# Altium schematic sheet DUT-PowerSupplyMB-Rev2b.SchDoc(DUT-PowerSupplyMB-Rev2b) (continued)

## Library data of the parts
C28 C106 = Cap: CAP CER 0.1UF 250V X7R 1206; Manufacturer: KEMET; Manufacturer Part Number: C1206C104KARECAUTO; Tolerance: 10%; Case Code (Imperial): 1206; Case Code (Metric): 3216; Case/Package: 1206; Component Kind: Standard; Library Name: Miscellaneous Devices.IntLib; Max Operating Temperature: 125°C; Min Operating Temperature: -55°C; Mount: Surface Mount; Packaging: Cut Tape; Ratings: AEC-Q200; Signal Integrity: Cap; Simulation: CAP; Supplier: Digi-Key; Value: 0.1uF
  C28: Supplier Part Number: 399-17175-1-ND
  C106: Supplier Part Number: 399-17175-6-ND
C104 = Cap: CAP CER 330PF 500V COG 1206; Manufacturer: Yageo; Manufacturer Part Number: CC1206KRNPOBBN331; Tolerance: 10%; Capacitance: 330pF; Case Code (Imperial): 1206; Case Code (Metric): 3216; Case/Package: 1206; Component Kind: Standard; Height: 0.6mm; Length: 0.126inch; Library Name: Miscellaneous Devices.IntLib; Max Operating Temperature: 125°C; Min Operating Temperature: -55°C; Mount: Surface Mount; Package Quantity: 4000; Packaging: Tape and Reel; Resistor Type: Ceramic; RoHS Compliant: Yes; Series: CC; Signal Integrity: Cap; Simulation: CAP; Supplier: Digi-Key; Supplier Part Number: 311-4477-1-ND; Termination: SMD/SMT; Thickness: 0.028inch; Value: 330pF; Voltage Rating (DC): 500V; Weight: 0.000571oz; Width: 0.063inch
C105 C113 C115 C117 C119 C123 C125 C127 C129 C133 = Cap: CAP CER 10NF 100V X7R 0805; Manufacturer: Samsung; Manufacturer Part Number: CL21B103KCANNNC; Dielectric Material: Ceramic; Dissipation Factor: 2.5%; Tolerance: 10%; Capacitance: 10nF; Case Code (Imperial): 0805; Case Code (Metric): 2012; Case/Package: 0805; Component Kind: Standard; Construction: Flat; Contact Plating: Tin; Depth: 1.25mm; Height: 0.65mm; Length: 2mm; Library Name: Miscellaneous Devices.IntLib; Material: Ceramic; Max Operating Temperature: 125°C; Min Operating Temperature: -55°C; Mount: Surface Mount; Number of Pins: 2; Package Quantity: 1; Packaging: Tape and Reel; Radiation Hardening: No; REACH SVHC: No SVHC; RoHS Compliant: Yes; Series: CL; Signal Integrity: Cap; Simulation: CAP; Supplier: Digi-Key; Supplier Part Number: 1276-1249-6-ND; Termination: SMD/SMT; Thickness: 0.03inch; Value: 10nF; Voltage Rating: 100V; Voltage Rating (DC): 100V; Width: 0.049inch
  C105 C115 C125 C129: Voltage: 100V
C107 = Cap: CAP CER 100PF 50V COG 0805; Manufacturer: KEMET; Manufacturer Part Number: C0805C101J5GACTU; Dielectric Material: Ceramic; Dissipation Factor: 0.1%; Temperature Coefficient: 30ppm/°C; Tolerance: 5%; Capacitance: 100pF; Case Code (Imperial): 0805; Case Code (Metric): 2012; Case/Package: 0805; Component Kind: Standard; Construction: Flat; Contact Material: Tin; Contact Plating: Tin,Matte; Depth: 1.25mm; Height: 0.78mm; Lead Free: Lead Free; Lead Pitch: 0.75mm; Length: 2mm; Library Name: Miscellaneous Devices.IntLib; Max Operating Temperature: 125°C; Min Operating Temperature: -55°C; Mount: Surface Mount,PCB; Number of Pins: 2; Package Quantity: 4000; Packaging: Tape and Reel; Radiation Hardening: No; REACH SVHC: No SVHC; RoHS Compliant: Yes; Series: C; Signal Integrity: Cap; Simulation: CAP; Supplier: Digi-Key; Supplier Part Number: 399-1122-6-ND; Termination: SMD/SMT; Thickness: 0.035inch; Value: 100pF; Voltage: 50V; Voltage Rating: 50V; Voltage Rating (DC): 50V; Width: 1.2mm
C108 C112 C114 C116 C118 C120 C124 C126 C128 C130 C132 C134 = Cap: CAP CER 1UF 50V X7R 0805; Manufacturer: Samsung; Manufacturer Part Number: CL21B105KBFNNNE; Dielectric Material: Ceramic; Tolerance: 10%; Capacitance: 1uF; Case Code (Imperial): 0805; Case Code (Metric): 2012; Case/Package: 0805; Component Kind: Standard; Construction: Flat; Depth: 1.25mm; Height: 1.25mm; Length: 2mm; Library Name: Miscellaneous Devices.IntLib; Max Operating Temperature: 125°C; Min Operating Temperature: -55°C; Mount: Surface Mount; Number of Pins: 2; Package Quantity: 1; Packaging: Tape and Reel; Radiation Hardening: No; REACH SVHC: No SVHC; RoHS Compliant: Yes; Series: CL; Signal Integrity: Cap; Simulation: CAP; Supplier: Digi-Key; Supplier Part Number: 1276-1029-6-ND; Termination: SMD/SMT; Thickness: 0.053inch; Value: 1uF; Voltage Rating: 50V; Voltage Rating (DC): 50V; Width: 0.049inch
C109 = Cap: CAP CER 47PF 250V C0G/NP0 0805; Manufacturer: KEMET; Manufacturer Part Number: C0805C470JAGAC7800; Tolerance: 5%; Capacitance: 47pF; Case Code (Imperial): 0805; Case Code (Metric): 2012; Case/Package: 0805; Component Kind: Standard; Library Name: Miscellaneous Devices.IntLib; Max Operating Temperature: 125°C; Min Operating Temperature: -55°C; Mount: Surface Mount; Packaging: Tape and Reel; Signal Integrity: Cap; Simulation: CAP; Supplier: Digi-Key; Supplier Part Number: 399-15051-6-ND; Value: 47pF
C111 C121 C131 C135 = Cap Pol1: Aluminum Electrolytic Capacitors - Leaded 100uF ±20% 25V Radial, 5x11mm RoHS; Manufacturer: Rubycon; Manufacturer Part Number: 25YXJ100M5X11; Impedance: 400mR; Lead Diameter: 0.5mm; Leakage Current: 3uA; Life (Hours): 5000Hour; Polarity: Polar; Ripple Current: 250mA; Capacitance: 100uF; Case/Package: Radial; Category: Aluminum Electrolytic Capacitors; Component Kind: Standard; ComponentLink1Description: https://datasheet.ciiva.com/11777/0900766b810307a1-11777001.pdf?src-supplier=RSComponents; ComponentLink1URL: https://datasheet.ciiva.com/11777/0900766b810307a1-11777001.pdf?src-supplier=RSComponents; ComponentLink2Description: https://datasheet.ciiva.com/41806/e-yxj-41806041.pdf?src-supplier=Digi-Key; ComponentLink2URL: https://datasheet.ciiva.com/41806/e-yxj-41806041.pdf?src-supplier=Digi-Key; ComponentLink3Description: https://datasheet.ciiva.com/11690/e-yxj-11690904.pdf?src-supplier=Avnet; ComponentLink3URL: https://datasheet.ciiva.com/11690/e-yxj-11690904.pdf?src-supplier=Avnet; Construction: RADIAL; Diameter: 5mm; Height: 11mm; Height - Seated (Max): 0.492inch; Lead Pitch: 2mm; Library Name: Miscellaneous Devices.IntLib; Max Operating Temperature: 105°C; Min Operating Temperature: -40°C; Mount: Through Hole; Package Quantity: 3000; Packaging: Bulk; Pricing 1: 1=0.25000, 10=0.17200, 50=0.10360, 100=0.08740, 500=0.05980, 1000=0.05290, 2500=0.05060, 5000=0.04600 (USD); Radiation Hardening: No; RoHS: Yes; RoHS Compliant: Yes; Series: YXJ; Signal Integrity: Cap Pol; Simulation: CAP; Stock 1: 7789; Supplier: Digi-Key; Supplier Part Number: 1189-2237-ND; Termination: Radial; Tolerance: 20%; Value: 100uF; Voltage Rating: 25V
D102 D103 D105 = Diode 1N4148: DIODE GP 75V 150MA SOD123FL; Manufacturer: Vishay Semiconductors; Manufacturer Part Number: 1N4148WFL-G3-08; Element Configuration: Single; Forward Voltage: 1.2V; Max Power Dissipation: 350mW; Max Repetitive Reverse Voltage (Vrrm): 100V; Max Reverse Current: 100uA; Max Surge Current: 500mA; Recovery Time: 4ns; Average Rectified Current: 150mA; Component Kind: Standard; ComponentLink1Description: Manufacturer Link; ComponentLink1URL: http://www.fairchildsemi.com/; ComponentLink2Description: Datasheet; ComponentLink2URL: http://www.fairchildsemi.com/ds/1N%2F1N4148.pdf; Contact Plating: Tin; Forward Current: 150mA; Height: 1mm; Length: 2.7mm; Library Name: Miscellaneous Devices.IntLib; Max Operating Temperature: 125°C; Max Reverse Voltage (DC): 75V; Min Operating Temperature: -65°C; Mount: Surface Mount; Number of Pins: 2; Package Quantity: 3000; Packaging: Tape and Reel; Peak Non-Repetitive Surge Current: 500mA; Reverse Recovery Time: 4ns; RoHS Compliant: Yes; Signal Integrity: 1N4148; Simulation: 1N4148; Supplier: Digi-Key; Supplier Part Number: 1N4148WFL-G3-08GIDKR-ND; Termination: SMD/SMT; Value: 1N4148; Width: 1.7mm
D104 D106 = Dual-Sch-Series-Separate: DIODE ARRAY SCHOTTKY 30V SOT23-3; Manufacturer: ON Semiconductor / Fairchild; Average Rectified Current: 200mA; Capacitance: 10pF; Current Rating: 200A; Forward Voltage: 800mV; Max Forward Surge Current (Ifsm): 600mA; Max Reverse Current: 2uA; Power Dissipation: 290mW; Recovery Time: 5ns; Case/Package: SOT-23; Component Kind: Standard; Contact Plating: Tin,Matte; Forward Current: 200mA; Height: 0.93mm; Lead Free: Lead Free; Length: 2.92mm; Library Name: MY_Diodes.SchLib; Manufacturer Part Number: BAT54S; Max Operating Temperature: 150°C; Max Repetitive Reverse Voltage (Vrrm): 30V; Max Reverse Leakage Current: 2uA; Max Reverse Voltage (DC): 30V; Max Surge Current: 600mA; Min Operating Temperature: -55°C; Mount: Surface Mount; Number of Pins: 3; Package Quantity: 1; Packaging: Tape and Reel; Peak Non-Repetitive Surge Current: 600mA; Radiation Hardening: No; REACH SVHC: No SVHC; Reverse Recovery Time: 5ns; RoHS Compliant: Yes; Supplier: Digi-Key; Supplier Part Number: BAT54SFSCT-ND; Value: BAT54S; Voltage Rating (DC): 30V; Weight: 0.025g; Width: 1.3mm
HS100 HS101 = HeatSink: Heat Sinks HTSNK TO-220 218 247 BLK ANODIZED; Manufacturer: Ohmite; Natural Thermal Resistance: 3°C/W; Shape: Fins,Rectangular; Thermal Resistance @ Forced Air Flow (100 LFM): 2°C/W; Case/Package: TO-220; Color: Black; Component Kind: Standard; Finish: Anodized; Height: 63.5mm; Height Off Base: 0.984inch; Length: 41.6mm; Library Name: MY_HeatSink.SchLib; Material: Aluminium; Mount: Bolt,PC Pin,Screw,Vertical; Package Cooled: TO-220; Package Quantity: 10; Packaging: Bulk; REACH SVHC: Unknown; RoHS Compliant: Yes; Series: F; Supplier: Digi-Key; Supplier Part Number: FA-T220-64E-ND; Type: Passive; Weight: 46g; Width: 25mm
J102 J105 = 2-Pin: 2.54mm 2pin; Manufacturer: TE Connectivity; Manufacturer Part Number: 640456-2; Approvals: CSA; Backwall/Post Interruptions: No (Without); Circuit Application: Signal; Connector System: Wire-to-Board; Connector Type: Connector,Pin,Plug,Header; Contact Current Rating: 5A; Contact Post Length: 3.56mm; Contact Resistance: 6mR; Contact Style: Straight; Gender: Male; Mating Connector Lock: Yes (With); Max Voltage Rating (AC): 250V; Number of Contacts: 2; PCB Mount Retention: Without; PCB Mounting Orientation: Vertical; PCB Thickness: 0.063inch; Plating Thickness: 3.81um; Tail Length: 0.14inch; Value: 640456-2; Category: Headers and Wire Housings; Color: Natural,White,Beige; Component Kind: Standard; ComponentLink1Description: https://datasheet.ciiva.com/709/eng-cd-640456-w1-709680.pdf?src-supplier=Digi-Key; ComponentLink1URL: https://datasheet.ciiva.com/709/eng-cd-640456-w1-709680.pdf?src-supplier=Digi-Key; ComponentLink2Description: https://datasheet.ciiva.com/3118/eng-ds-82056-mta-0608-3118497.pdf?src-supplier=Avnet; ComponentLink2URL: https://datasheet.ciiva.com/3118/eng-ds-82056-mta-0608-3118497.pdf?src-supplier=Avnet; ComponentLink3Description: https://datasheet.ciiva.com/16401/eng-ds-82056-mta-0608-16401289.pdf?src-supplier=Future+Electronics; ComponentLink3URL: https://datasheet.ciiva.com/16401/eng-ds-82056-mta-0608-16401289.pdf?src-supplier=Future+Electronics; ComponentLink4Description: https://datasheet.ciiva.com/25617/0900766b80027008-25617244.pdf?src-supplier=RSComponents; ComponentLink4URL: https://datasheet.ciiva.com/25617/0900766b80027008-25617244.pdf?src-supplier=RSComponents; ComponentLink5Description: https://datasheet.ciiva.com/14156/04384301-14156820.pdf?src-supplier=Distrelec; ComponentLink5URL: https://datasheet.ciiva.com/14156/04384301-14156820.pdf?src-supplier=Distrelec; ComponentLink6Description: https://datasheet.ciiva.com/11746/0900766b80eb7fdd-11746365.pdf?src-supplier=RSComponents; ComponentLink6URL: https://datasheet.ciiva.com/11746/0900766b80eb7fdd-11746365.pdf?src-supplier=RSComponents; ComponentLink7Description: https://datasheet.ciiva.com/7128/1866390-7128742.pdf?src-supplier=Newark; ComponentLink7URL: https://datasheet.ciiva.com/7128/1866390-7128742.pdf?src-supplier=Newark; ComponentLink8Description: https://datasheet.ciiva.com/16505/eng-ds-82056-mta-0608-16505419.pdf?src-supplier=Avnet+Asia; ComponentLink8URL: https://datasheet.ciiva.com/16505/eng-ds-82056-mta-0608-16505419.pdf?src-supplier=Avnet+Asia; ComponentLink9Description: https://datasheet.ciiva.com/18620/1713017-18620870.pdf?src-supplier=Digi-Key; ComponentLink9URL: https://datasheet.ciiva.com/18620/1713017-18620870.pdf?src-supplier=Digi-Key; ComponentLink10Description: https://datasheet.ciiva.com/16047/1989748-16047438.pdf?src-supplier=Onlinecomponents.com; ComponentLink10URL: https://datasheet.ciiva.com/16047/1989748-16047438.pdf?src-supplier=Onlinecomponents.com; ComponentLink11Description: https://datasheet.ciiva.com/11758/0900766b811d404e-11758587.pdf?src-supplier=RSComponents; ComponentLink11URL: https://datasheet.ciiva.com/11758/0900766b811d404e-11758587.pdf?src-supplier=RSComponents; ComponentLink12Description: https://datasheet.ciiva.com/6746/combiconspring-cagepcbterm-blocks-6746175.pdf?src-supplier=Digi-Key; ComponentLink12URL: https://datasheet.ciiva.com/6746/combiconspring-cagepcbterm-blocks-6746175.pdf?src-supplier=Digi-Key; ComponentLink13Description: https://datasheet.ciiva.com/14607/70055059-14607702.pdf?src-supplier=Allied+Electronics; ComponentLink13URL: https://datasheet.ciiva.com/14607/70055059-14607702.pdf?src-supplier=Allied+Electronics; ComponentLink14Description: https://datasheet.ciiva.com/16051/1988956-16051359.pdf?src-supplier=Onlinecomponents.com; ComponentLink14URL: https://datasheet.ciiva.com/16051/1988956-16051359.pdf?src-supplier=Onlinecomponents.com; ComponentLink15Description: https://datasheet.ciiva.com/26340/567541-da-01-de-printklemme-1988956-250-st-26340451.pdf?src-supplier=Conrad; ComponentLink15URL: https://datasheet.ciiva.com/26340/567541-da-01-de-printklemme-1988956-250-st-26340451.pdf?src-supplier=Conrad; ComponentLink16Description: https://datasheet.ciiva.com/824/combiconcompactseries-824224.pdf?src-supplier=Digi-Key; ComponentLink16URL: https://datasheet.ciiva.com/824/combiconcompactseries-824224.pdf?src-supplier=Digi-Key; ComponentLink17Description: https://datasheet.ciiva.com/14609/70055171-14609100.pdf?src-supplier=Allied+Electronics; ComponentLink17URL: https://datasheet.ciiva.com/14609/70055171-14609100.pdf?src-supplier=Allied+Electronics; Contact Gender: Male; Contact Material: Copper Alloy; Contact Mating Length: 7.49mm; Contact Plating: Tin; Contact Retention: No (Without); Contact Shape: Square; Contact Type: Male Pin; Current Rating: 5A; Depth: 5.72mm; ELV: Compliant; Fastening Type: Friction; Flammability Rating: UL94 V-0; Height: 7.87mm; Housing Color: Natural; Housing Material: Polyester; Lead Free: Lead Free; Lead Pitch: 2.54mm; Length: 7.62mm; Library Name: MY_CONNECTORS.SchLib; Mating Alignment: No (Without); Mating Retention: No (Without); Max Operating Temperature: 221°C; Min Operating Temperature: -67°C; Mount: Through Hole; Mounting Ears: No (Without); Number of Poles: 2; Number of Positions: 2; Number of Rows: 1; Orientation: Straight; Package Quantity: 5000; Packaging: Bulk; Panel Mount Retention: No (Without); PCB Mount Alignment: No (Without); Pitch: 2.54mm; Pricing 1: 1=0.13000, 10=0.10400, 100=0.07700, 500=0.06374, 1000=0.05578, 5000=0.04781, 10000=0.04648 (USD); Radiation Hardening: No; REACH SVHC: No SVHC; RoHS: Yes; RoHS Compliant: Yes; Sealable: No (Without); Series: MTA-100; Shrouded: No (Without); Stock 1: 18986; Strain Relief: No (Without); Supplier: Digi-Key; Supplier Part Number: A1921-ND; Termination: Solder; Voltage Rating: 250V; Voltage Rating (AC): 250V; Working Voltage: 250V
J103 J106 = 3-Pin: 2.54mm 3 pin; Manufacturer: TE Connectivity; Manufacturer Part Number: 640456-3; Approvals: CSA; Backwall/Post Interruptions: No (Without); Circuit Application: Signal; Connector System: Wire-to-Board; Connector Type: Connector,Pin,Plug,Header; Contact Current Rating: 5A; Contact Post Length: 3.56mm; Contact Resistance: 6mR; Contact Style: Straight; Gender: Male; Mating Connector Lock: Yes (With); Max Voltage Rating (AC): 250V; Number of Contacts: 3; PCB Mount Retention: Without; PCB Mounting Orientation: Vertical; PCB Thickness: 0.063inch; Plating Thickness: 3.81um; Tail Length: 0.14inch; Value: 640456-3; Category: Connectors; Color: Beige,White; Component Kind: Standard; ComponentLink1Description: http://www.farnell.com/datasheets/2043229.pdf?src-supplier=Farnell; ComponentLink1URL: http://www.farnell.com/datasheets/2043229.pdf?src-supplier=Farnell; ComponentLink2Description: http://www.farnell.com/datasheets/2043229.pdf?src-supplier=Element14; ComponentLink2URL: http://www.farnell.com/datasheets/2043229.pdf?src-supplier=Element14; ComponentLink3Description: http://www.farnell.com/datasheets/2043229.pdf?src-supplier=Newark; ComponentLink3URL: http://www.farnell.com/datasheets/2043229.pdf?src-supplier=Newark; ComponentLink4Description: https://www.te.com/commerce/DocumentDelivery/DDEController?Action=srchrtrv&DocNm=640456&DocType=Customer+Drawing&DocLang=English&src-supplier=Digi-Key; ComponentLink4URL: https://www.te.com/commerce/DocumentDelivery/DDEController?Action=srchrtrv&DocNm=640456&DocType=Customer+Drawing&DocLang=English&src-supplier=Digi-Key; ComponentLink5Description: https://datasheet.ciiva.com/11746/0900766b80027008-11746172.pdf?src-supplier=RSComponents; ComponentLink5URL: https://datasheet.ciiva.com/11746/0900766b80027008-11746172.pdf?src-supplier=RSComponents; ComponentLink6Description: https://datasheet.ciiva.com/6005/1712135-6005440.pdf?src-supplier=Farnell; ComponentLink6URL: https://datasheet.ciiva.com/6005/1712135-6005440.pdf?src-supplier=Farnell; ComponentLink7Description: https://datasheet.ciiva.com/19474/613940-19474164.pdf?src-supplier=Jameco; ComponentLink7URL: https://datasheet.ciiva.com/19474/613940-19474164.pdf?src-supplier=Jameco; ComponentLink8Description: https://datasheet.ciiva.com/16456/eng-ds-82056-mta-0608-16456886.pdf?src-supplier=Future+Electronics; ComponentLink8URL: https://datasheet.ciiva.com/16456/eng-ds-82056-mta-0608-16456886.pdf?src-supplier=Future+Electronics; ComponentLink9Description: https://datasheet.ciiva.com/14156/04384301-14156821.pdf?src-supplier=Distrelec; ComponentLink9URL: https://datasheet.ciiva.com/14156/04384301-14156821.pdf?src-supplier=Distrelec; ComponentLink10Description: https://datasheet.ciiva.com/16656/eng-ds-82056-mta-0608-16656523.pdf?src-supplier=Avnet+Europe; ComponentLink10URL: https://datasheet.ciiva.com/16656/eng-ds-82056-mta-0608-16656523.pdf?src-supplier=Avnet+Europe; ComponentLink11Description: https://datasheet.ciiva.com/30127/8852eng-ds-82056-mta-0608-30127480.pdf?src-supplier=Onlinecomponents.com; ComponentLink11URL: https://datasheet.ciiva.com/30127/8852eng-ds-82056-mta-0608-30127480.pdf?src-supplier=Onlinecomponents.com; ComponentLink12Description: https://datasheet.ciiva.com/14637/70083643-14637750.pdf?src-supplier=Allied+Electronics; ComponentLink12URL: https://datasheet.ciiva.com/14637/70083643-14637750.pdf?src-supplier=Allied+Electronics; ComponentLink13Description: https://datasheet.ciiva.com/7128/eng-ds-82056-mta-0608-7128744.pdf?src-supplier=Newark; ComponentLink13URL: https://datasheet.ciiva.com/7128/eng-ds-82056-mta-0608-7128744.pdf?src-supplier=Newark; ComponentLink14Description: https://datasheet.ciiva.com/709/eng-cd-640456-w2-709688.pdf?src-supplier=Digi-Key; ComponentLink14URL: https://datasheet.ciiva.com/709/eng-cd-640456-w2-709688.pdf?src-supplier=Digi-Key; ComponentLink15Description: https://datasheet.ciiva.com/16047/1984976-16047357.pdf?src-supplier=Onlinecomponents.com; ComponentLink15URL: https://datasheet.ciiva.com/16047/1984976-16047357.pdf?src-supplier=Onlinecomponents.com; ComponentLink16Description: https://datasheet.ciiva.com/11758/0900766b811d406d-11758596.pdf?src-supplier=RSComponents; ComponentLink16URL: https://datasheet.ciiva.com/11758/0900766b811d406d-11758596.pdf?src-supplier=RSComponents; ComponentLink17Description: https://datasheet.ciiva.com/26840/getdatasheetpartid-743835-26840596.pdf?src-supplier=Verical; ComponentLink17URL: https://datasheet.ciiva.com/26840/getdatasheetpartid-743835-26840596.pdf?src-supplier=Verical; ComponentLink18Description: https://datasheet.ciiva.com/6752/combiconspring-cagepcbterm-blocks-6752927.pdf?src-supplier=Digi-Key; ComponentLink18URL: https://datasheet.ciiva.com/6752/combiconspring-cagepcbterm-blocks-6752927.pdf?src-supplier=Digi-Key; ComponentLink19Description: https://datasheet.ciiva.com/16051/1988969-16051361.pdf?src-supplier=Onlinecomponents.com; ComponentLink19URL: https://datasheet.ciiva.com/16051/1988969-16051361.pdf?src-supplier=Onlinecomponents.com; ComponentLink20Description: https://datasheet.ciiva.com/823/combiconcompactseries-823768.pdf?src-supplier=Digi-Key; ComponentLink20URL: https://datasheet.ciiva.com/823/combiconcompactseries-823768.pdf?src-supplier=Digi-Key; ComponentLink21Description: https://datasheet.ciiva.com/14609/70055172-14609515.pdf?src-supplier=Allied+Electronics; ComponentLink21URL: https://datasheet.ciiva.com/14609/70055172-14609515.pdf?src-supplier=Allied+Electronics; Contact Finish - Mating: TIN; Contact Gender: Male; Contact Material: Copper Alloy; Contact Mating Length: 7.49mm; Contact Plating: Tin; Contact Retention: No (Without); Contact Shape: Square; Contact Type: Male Pin; Current Rating: 5A; Depth: 5.72mm; ELV: Compliant; Fastening Type: Friction; Flammability Rating: UL94 V-0; Height: 7.87mm; Housing Color: Natural; Housing Material: Polyester; Insulation Color: Natural; Insulation Material: Thermoplastic; Lead Free: Lead Free; Length: 0.4inch; Library Name: MY_CONNECTORS.SchLib; Mating Alignment: No (Without); Mating Retention: No (Without); Max Operating Temperature: 221°C; Min Operating Temperature: -67°C; Mount: Through Hole; Mounting Ears: No (Without); Number of Positions: 3; Number of Rows: 1; Orientation: Straight; Package Quantity: 5000; Packaging: Bulk; Panel Mount Retention: No (Without); PCB Mount Alignment: No (Without); Pitch: 2.54mm; Pricing 1: 1=0.16000, 10=0.13500, 100=0.10360, 500=0.08766, 1000=0.07173, 5000=0.06376, 10000=0.05977 (USD); Radiation Hardening: No; REACH SVHC: Unknown; RoHS: Yes; RoHS Compliant: Yes; Sealable: No (Without); Series: MTA-100; Shrouded: No (Without); Stock 1: 96416; Strain Relief: No (Without); Supplier: Digi-Key; Supplier Part Number: A19470-ND; Termination: Solder; Voltage Rating: 250V; Voltage Rating (AC): 250V
Pot100 Pot102 = POT-Single: TRIMMER 50 OHM 0.5W TH; Manufacturer: Nidec Copal; Manufacturer Part Number: CT-6EP500; Max Power Dissipation: 500mW; Number of Turns: 1; Temperature Coefficient: 100ppm/°C; Tolerance: 10%; Component Kind: Standard; Contact Plating: Tin; Length: 0.228inch; Max Operating Temperature: 120°C; Min Operating Temperature: -55°C; Mount: Through Hole; Package Quantity: 50; Packaging: Bulk; Power Rating: 500mW; Resistor Type: Cermet; Series: CT6; Supplier: Digi-Key; Supplier Part Number: CT6EP500-ND; Termination: PC Pin; Library Name: MY_POTS.SchLib
Pot101 = POT-Single: TRIMMER 500 OHM 0.5W TH; Manufacturer: Nidec Copal; Manufacturer Part Number: CT-6EP501; Max Power Dissipation: 500mW; Number of Turns: 1; Temperature Coefficient: 100ppm/°C; Tolerance: 10%; Color: Blue; Component Kind: Standard; Contact Plating: Tin; Lead Free: Lead Free; Length: 0.228inch; Max Operating Temperature: 120°C; Min Operating Temperature: -55°C; Mount: Through Hole; Package Quantity: 50; Packaging: Bulk; Resistor Type: Cermet; Series: CT6; Supplier: Digi-Key; Supplier Part Number: CT6EP501-ND; Termination: PC Pin; Weight: 510mg; Library Name: MY_POTS.SchLib
Pot103 = POT-Single: TRIMMER 2K OHM 0.2W TH; Manufacturer: Bourns; Manufacturer Part Number: 3306P-1-202; Max Power Dissipation: 200mW; Number of Turns: 1; Pitch: 2.5mm; Resistance: 2kR; Resistance Tolerance: 25%; Temperature Coefficient: 250ppm/°C; Voltage Rating: 100V; Color: Blue; Component Kind: Standard; Depth: 8.1mm; Diameter: 6.81mm; Height: 7.62mm; Lead Free: Lead Free; Length: 6.81mm; Max Operating Temperature: 100°C; Military Standard: Not; Min Operating Temperature: -25°C; Mount: Through Hole,PCB; Package Quantity: 200; Packaging: Bulk; Power Rating: 200mW; Radiation Hardening: No; REACH SVHC: No SVHC; Resistor Type: Cermet; Series: Trimpot® 3306 - Open Frame; Supplier: Digi-Key; Supplier Part Number: 3306P-202-ND; Taper: Linear; Technology: CERMET; Termination: Pin; Tolerance: 25%; Weight: 360mg; Width: 6.81mm; Library Name: MY_POTS.SchLib
Q103 Q113 = Dual-PNP: Dual Matched Pair PNP SOT363-6M; Manufacturer: NXP Semiconductors; Manufacturer Part Number: BCM857DS,135; Collector Base Voltage (VCBO): 50V; Collector Emitter Breakdown Voltage: 45V; Collector-emitter Voltage-Max: 400mV; Emitter Base Voltage (VEBO): 5V; Frequency: 175MHz; Max Collector Current: 100mA; Max Power Dissipation: 380mW; Number of Elements: 2; Case/Package: TSOP; Collector Emitter Voltage (VCEO): 45V; Component Kind: Standard; Contact Plating: Tin,Matte; Element Configuration: Dual; Gain Bandwidth Product: 175MHz; Library Name: MY_Transistor.SchLib; Max Breakdown Voltage: 45V; Max Operating Temperature: 150°C; Min Operating Temperature: -65°C; Mount: Surface Mount; Number of Pins: 6; Package Quantity: 1; Packaging: Tape and Reel; Polarity: PNP; Power Dissipation: 380mW; RoHS Compliant: Yes; Series: -; Supplier: Digi-Key; Supplier Part Number: 1727-5460-1-ND; Transition Frequency: 175MHz; Value: BCM857DS
Q104 Q105 = NMOS-2: N-Channel Power MOSFET; Manufacturer: STMicroelectronics; Manufacturer Part Number: STW28N60M2; Continuous Drain Current (ID): 24A; Drain to Source Breakdown Voltage: 600V; Drain to Source Resistance: 120mR; Element Configuration: Single; Fall Time: 8ns; Gate to Source Voltage (Vgs): 25V; Input Capacitance: 1.37nF; Max Power Dissipation: 190W; Number of Channels: 1; Power Dissipation: 170W; Rds On Max: 150mR; Rise Time: 7.2ns; Turn-Off Delay Time: 100ns; Turn-On Delay Time: 14.5ns; Case/Package: TO-247; Component Kind: Standard; ComponentLink1Description: Manufacturer Link; ComponentLink1URL: http://www.irf.com/; Contact Plating: Tin,Matte; Drain to Source Voltage (Vdss): 600V; Height: 20.15mm; Lead Free: Lead Free; Length: 15.75mm; Library Name: Miscellaneous Devices.IntLib; Max Operating Temperature: 150°C; Min Operating Temperature: -55°C; Mount: Through Hole; Number of Elements: 1; Number of Pins: 3; Package Quantity: 30; Packaging: Rail/Tube; Simulation: IRF1010; Supplier: Digi-Key; Supplier Part Number: 497-14292-5-ND; Value: STW28N60; Weight: 1.340411oz; Width: 5.15mm
Q106 Q108 Q109 Q111 Q112 = 2N7000: N-CH 25V 1.25 W Power Mosfet SOT-23; Manufacturer: International Rectifier; Manufacturer Part Number: IRFML8244TRPBF; Continuous Drain Current (ID): 5.8A; Drain to Source Resistance: 41mR; Element Configuration: Single; Fall Time: 2.9ns; Gate to Source Voltage (Vgs): 20V; Input Capacitance: 430pF; Max Power Dissipation: 1.25W; Nominal Vgs: 1.7V; Number of Elements: 1; Rds On Max: 24mR; Recovery Time: 17ns; Rise Time: 2.1ns; Turn-Off Delay Time: 9ns; Turn-On Delay Time: 2.7ns; Case/Package: SOT-23; Component Kind: Standard; Drain to Source Breakdown Voltage: 25V; Drain to Source Voltage (Vdss): 25V; Drain-source On Resistance-Max: 41MR; Height: 1.02mm; Lead Free: Lead Free; Length: 3.04mm; Library Name: Philips Discrete MOSFET - Low Power.IntLib; Max Operating Temperature: 150°C; Min Operating Temperature: -55°C; Mount: Surface Mount; Number of Pins: 3; On-State Resistance: 41MR; Package Quantity: 1; Packaging: Tape and Reel; Power Dissipation: 1.25W; Radiation Hardening: No; REACH SVHC: No SVHC; Series: HEXFET®; Simulation: 2N7000; Supplier: Digi-Key; Supplier Part Number: IRFML8244TRPBFDKR-ND; Threshold Voltage: 1.7V; Value: IRFML8244; Width: 1.4mm
Q107 Q115 = Dual-NPN: Dual Matched Pair NPN SOT363-6M; Manufacturer: NXP Semiconductors; Manufacturer Part Number: BCM847DS,135; Collector Base Voltage (VCBO): 50V; Collector Emitter Breakdown Voltage: 45V; Collector-emitter Voltage-Max: 400mV; Emitter Base Voltage (VEBO): 6V; Frequency: 250MHz; Max Collector Current: 100mA; Max Power Dissipation: 380mW; Number of Elements: 2; Case/Package: TSOP; Collector Emitter Voltage (VCEO): 45V; Component Kind: Standard; Contact Plating: Tin; Element Configuration: Dual; Gain Bandwidth Product: 250MHz; Library Name: MY_Transistor.SchLib; Max Breakdown Voltage: 45V; Max Operating Temperature: 150°C; Min Operating Temperature: -65°C; Mount: Surface Mount; Number of Pins: 6; Package Quantity: 1; Packaging: Tape and Reel; Polarity: NPN; Power Dissipation: 380mW; Radiation Hardening: No; RoHS Compliant: Yes; Series: -; Supplier: Digi-Key; Supplier Part Number: 1727-5458-1-ND; Transition Frequency: 250MHz; Value: BCM847DS
Q110 = 2N3906: TRANS PNP 40V 0.2A SOT23; Manufacturer: ON Semiconductor; Manufacturer Part Number: MMBT3906LT1G; Current - Collector (Ic) (Max): 200mA; DC Current Gain (hFE) (Min) @ Ic, Vce: 100 @ 10mA, 1V; Frequency - Transition: 250MHz; Power - Max: 300mW; Vce Saturation (Max) @ Ib, Ic: 400mV @ 5mA, 50mA; Category: Discrete Semiconductor Products; Component Kind: Standard; Library Name: Miscellaneous Devices.IntLib; Mounting Type: Surface Mount; Package / Case: TO-236-3, SC-59, SOT-23-3; Packaging: Cut Tape (CT); RoHS: RoHS Compliant; Signal Integrity: 2N3906; Simulation: 2N3906; Supplier: Digi-Key; Supplier Device Package: SOT-23-3 (TO-236); Supplier Part Number: MMBT3906LT1GOSCT-ND; Transistor Type: PNP; Value: 2N3906; Voltage - Collector Emitter Breakdown (Max): 40V
Q114 = 2N3904: TRANS NPN 40V 0.2A SOT23; Manufacturer: ON Semiconductor; Manufacturer Part Number: MMBT3904LT1G; Current - Collector (Ic) (Max): 200mA; DC Current Gain (hFE) (Min) @ Ic, Vce: 100 @ 10mA, 1V; Frequency - Transition: 300MHz; Power - Max: 300mW; Vce Saturation (Max) @ Ib, Ic: 300mV @ 5mA, 50mA; Category: Discrete Semiconductor Products; Code_JEDEC: TO-92A; Component Kind: Standard; ComponentLink1Description: Manufacturer Link; ComponentLink1URL: http://www.fairchildsemi.com/; ComponentLink2Description: Datasheet; ComponentLink2URL: http://www.fairchildsemi.com/ds/2N%2F2N3904.pdf; LatestRevisionDate: 15-Jan-2003; LatestRevisionNote: PCB Footprint 'BCY-W3/D4.7' replaced; Library Name: My_Miscellaneous Devices.SchLib; Mounting Type: Surface Mount; Operating Temperature: -55°C ~ 150°C (TJ); Package / Case: TO-236-3, SC-59, SOT-23-3; PackageVersion: Sep-1998; Packaging: Cut Tape (CT); Part Status: Active; RoHS: RoHS Compliant; Signal Integrity: 2N3904; Simulation: 2N3904; Supplier: Digi-Key; Supplier Device Package: SOT-23-3 (TO-236); Supplier Part Number: MMBT3904LT1GOSCT-ND; Transistor Type: NPN; Value: 2N3904; Voltage - Collector Emitter Breakdown (Max): 40V
R2 = Res3: Res Thick Film 1206 301K Ohm 1% 0.25W ±100ppm/°C Molded SMD Paper T/R; Manufacturer Part Number: RMCF1206FT301K; Max Power Dissipation: 250mW; Resistance: 301kR; Case Code (Imperial): 1206; Case Code (Metric): 3216; Case/Package: 1206; Category: Chip SMD Resistors; Component Kind: Standard; ComponentLink1Description: http://components.arrow.com/part/dataview/40949670S6804816N1174?src-supplier=Arrow+Electronics; ComponentLink1URL: http://components.arrow.com/part/dataview/40949670S6804816N1174?src-supplier=Arrow+Electronics; ComponentLink2Description: https://www.seielect.com/catalog/SEI-RMCF_RMCP.pdf?src-supplier=Avnet; ComponentLink2URL: https://www.seielect.com/catalog/SEI-RMCF_RMCP.pdf?src-supplier=Avnet; ComponentLink3Description: http://www.seielect.com/Catalog/SEI-RMCF_RMCP.pdf?src-supplier=Digi-Key; ComponentLink3URL: http://www.seielect.com/Catalog/SEI-RMCF_RMCP.pdf?src-supplier=Digi-Key; ComponentLink4Description: https://www.seielect.com/Catalog/SEI-RMCF_RMCP.pdf?src-supplier=Digi-Key; ComponentLink4URL: https://www.seielect.com/Catalog/SEI-RMCF_RMCP.pdf?src-supplier=Digi-Key; ComponentLink5Description: https://www.seielect.com/catalog/SEI-Packaging.pdf?src-supplier=Digi-Key; ComponentLink5URL: https://www.seielect.com/catalog/SEI-Packaging.pdf?src-supplier=Digi-Key; ComponentLink6Description: http://components.arrow.com/part/dataview/40949655S7370296N1174?src-supplier=Arrow+Electronics; ComponentLink6URL: http://components.arrow.com/part/dataview/40949655S7370296N1174?src-supplier=Arrow+Electronics; Contact Plating: Tin,Matte; Features: Automotive AEC-Q200; Height: 0.028inch; Length: 0.126inch; Library Name: Miscellaneous Devices.IntLib; Max Operating Temperature: 155°C; Min Operating Temperature: -55°C; Mount: Surface Mount; Number of Terminations: 2; Packaging: Tape and Reel; Pricing 1: 1=0.10000, 10=0.03600, 100=0.01460, 1000=0.00655, 2500=0.00569 (USD); Radiation Hardening: No; Resistor Type: Thick Film; RoHS: Yes; RoHS Compliant: Yes; Series: RMCF; Signal Integrity: Res; Simulation: RESISTOR; Supplier: Digi-Key; Supplier Part Number: RMCF1206FT301KCT-ND; Temperature Coefficient: 100ppm/°C; Tolerance: 1%; Width: 0.063inch
R3 R7 = Res3: RES 33.2K OHM 1% 1/4W 1206; Manufacturer: Stackpole Electronics; Manufacturer Part Number: RMCF1206FT33K2; Max Power Dissipation: 250mW; Resistance: 33.2kR; Temperature Coefficient: 100ppm/°C; Case Code (Imperial): 1206; Case Code (Metric): 3216; Case/Package: 1206; Component Kind: Standard; Composition: Thick Film; Contact Plating: Matte,Tin; Depth: 1.6mm; Features: Automotive AEC-Q200; Height: 0.028inch; Lead Free: Lead Free; Length: 0.126inch; Library Name: Miscellaneous Devices.IntLib; Max Operating Temperature: 155°C; Military Standard: Not; Min Operating Temperature: -55°C; Mount: Surface Mount; Number of Terminations: 2; Packaging: Tape and Reel; Power Rating: 250mW; Radiation Hardening: No; Signal Integrity: Res; Simulation: RESISTOR; Supplier: Digi-Key; Supplier Part Number: RMCF1206FT33K2CT-ND; Tolerance: 1%; Width: 0.063inch
R6 = Res3: Res Thick Film 1206 301K Ohm 1% 0.25W ±100ppm/°C Molded SMD Paper T/R; Manufacturer: Stackpole Electronics; Manufacturer Part Number: RMCF1206FT301K; Max Power Dissipation: 250mW; Resistance: 301kR; Case Code (Imperial): 1206; Case Code (Metric): 3216; Case/Package: 1206; Category: Chip SMD Resistors; Component Kind: Standard; ComponentLink1Description: http://components.arrow.com/part/dataview/40949670S6804816N1174?src-supplier=Arrow+Electronics; ComponentLink1URL: http://components.arrow.com/part/dataview/40949670S6804816N1174?src-supplier=Arrow+Electronics; ComponentLink2Description: https://www.seielect.com/catalog/SEI-RMCF_RMCP.pdf?src-supplier=Avnet; ComponentLink2URL: https://www.seielect.com/catalog/SEI-RMCF_RMCP.pdf?src-supplier=Avnet; ComponentLink3Description: http://www.seielect.com/Catalog/SEI-RMCF_RMCP.pdf?src-supplier=Digi-Key; ComponentLink3URL: http://www.seielect.com/Catalog/SEI-RMCF_RMCP.pdf?src-supplier=Digi-Key; ComponentLink4Description: https://www.seielect.com/Catalog/SEI-RMCF_RMCP.pdf?src-supplier=Digi-Key; ComponentLink4URL: https://www.seielect.com/Catalog/SEI-RMCF_RMCP.pdf?src-supplier=Digi-Key; ComponentLink5Description: https://www.seielect.com/catalog/SEI-Packaging.pdf?src-supplier=Digi-Key; ComponentLink5URL: https://www.seielect.com/catalog/SEI-Packaging.pdf?src-supplier=Digi-Key; ComponentLink6Description: http://components.arrow.com/part/dataview/40949655S7370296N1174?src-supplier=Arrow+Electronics; ComponentLink6URL: http://components.arrow.com/part/dataview/40949655S7370296N1174?src-supplier=Arrow+Electronics; Contact Plating: Tin,Matte; Features: Automotive AEC-Q200; Height: 0.028inch; Length: 0.126inch; Library Name: Miscellaneous Devices.IntLib; Max Operating Temperature: 155°C; Min Operating Temperature: -55°C; Mount: Surface Mount; Number of Terminations: 2; Packaging: Tape and Reel; Pricing 1: 1=0.10000, 10=0.03600, 100=0.01460, 1000=0.00655, 2500=0.00569 (USD); Radiation Hardening: No; Resistor Type: Thick Film; RoHS: Yes; RoHS Compliant: Yes; Series: RMCF; Signal Integrity: Res; Simulation: RESISTOR; Supplier: Digi-Key; Supplier Part Number: RMCF1206FT301KCT-ND; Temperature Coefficient: 100ppm/°C; Tolerance: 1%; Width: 0.063inch
R8 = Res3: RES 7.5K OHM 1% 1/4W 0805; Manufacturer: KOA Speer; Manufacturer Part Number: RK73H2ATTD7501F; Power Rating: 125mW; Resistance: 7.5kR; Temperature Coefficient: 100ppm/°C; Voltage Rating: 150V; Case Code (Imperial): 0805; Case Code (Metric): 2012; Case/Package: 0805; Component Kind: Standard; Construction: Rectangular; Depth: 1.25mm; Height: 0.5mm; Lead Free: Lead Free; Length: 2mm; Library Name: Miscellaneous Devices.IntLib; Max Operating Temperature: 155°C; Military Standard: Not; Min Operating Temperature: -55°C; Mount: Surface Mount; Number of Pins: 2; Package Quantity: 5000; Packaging: Tape and Reel; Resistance Tolerance: 1%; Resistor Type: Thick Film; RoHS Compliant: Yes; Series: RK73H; Signal Integrity: Res; Simulation: RESISTOR; Supplier: Digi-Key; Supplier Part Number: 2019-RK73H2ATTD7501FCT-ND; Technology: THICK FILM; Termination: SMD/SMT; Tolerance: 1%; Value: 7.5K; Voltage Rating (DC): 150V; Width: 1.25mm
R103 = Res3: RES 100 OHM 1% 1/4W 1206; Manufacturer: Stackpole Electronics; Manufacturer Part Number: RMCF1206FT100R; Max Power Dissipation: 250mW; Resistance: 100R; Temperature Coefficient: 100ppm/°C; Case Code (Imperial): 1206; Case Code (Metric): 3216; Case/Package: 1206; Component Kind: Standard; Construction: Rectangular; Contact Plating: Tin,Matte; Depth: 1.6mm; Features: Automotive AEC-Q200; Height: 0.028inch; Lead Free: Lead Free; Length: 0.126inch; Library Name: Miscellaneous Devices.IntLib; Max Operating Temperature: 155°C; Military Standard: Not; Min Operating Temperature: -55°C; Mount: Surface Mount; Number of Terminations: 2; Packaging: Tape and Reel; Power Rating: 250mW; Radiation Hardening: No; Resistor Type: Thick Film; RoHS Compliant: Yes; Series: RMCF; Signal Integrity: Res; Simulation: RESISTOR; Size Code: 1206; Supplier: Digi-Key; Supplier Part Number: RMCF1206FT100RCT-ND; Tolerance: 1%; Value: 100; Width: 0.063inch
R105 R106 = Res3: RES 200 OHM 1% 1/4W 0805; Manufacturer: KOA Speer; Manufacturer Part Number: RK73H2ATTD2000F; Power Rating: 125mW; Resistance: 200R; Temperature Coefficient: 100ppm/°C; Voltage Rating: 150V; Case Code (Imperial): 0805; Case Code (Metric): 2012; Case/Package: 0805; Component Kind: Standard; Construction: Rectangular; Depth: 1.25mm; Height: 0.5mm; Lead Free: Lead Free; Length: 2mm; Library Name: Miscellaneous Devices.IntLib; Max Operating Temperature: 155°C; Military Standard: Not; Min Operating Temperature: -55°C; Mount: Surface Mount; Number of Pins: 2; Package Quantity: 5000; Packaging: Tape and Reel; REACH SVHC: Unknown; Resistance Tolerance: 1%; Resistor Type: Thick Film; RoHS Compliant: Yes; Series: RK73H; Signal Integrity: Res; Simulation: RESISTOR; Supplier: Digi-Key; Supplier Part Number: 2019-RK73H2ATTD2000FDKR-ND; Technology: THICK FILM; Termination: SMD/SMT; Tolerance: 1%; Value: 200; Voltage Rating (DC): 150V; Width: 1.25mm
R108 = Res3: RES 1M OHM 1% 1/8W 0805; Manufacturer: KOA Speer; Manufacturer Part Number: RK73H2ATTD1004F; Max Power Dissipation: 250mW; Power Rating: 125mW; Resistance: 1MR; Temperature Coefficient: 100ppm/°C; Voltage Rating: 150V; Case Code (Imperial): 0805; Case Code (Metric): 2012; Case/Package: 0805; Component Kind: Standard; Composition: Thick Film; Construction: Rectangular; Contact Plating: Matte,Tin; Depth: 1.25mm; Features: Automotive AEC-Q200; Height: 0.5mm; Lead Free: Lead Free; Length: 2mm; Library Name: Miscellaneous Devices.IntLib; Max Operating Temperature: 155°C; Military Standard: Not; Min Operating Temperature: -55°C; Mount: Surface Mount; Number of Pins: 2; Number of Terminations: 2; Package Quantity: 5000; Packaging: Tape and Reel; Radiation Hardening: No; REACH SVHC: Unknown; Resistance Tolerance: 1%; Resistor Type: Thick Film; RoHS Compliant: Yes; Series: RK73H; Signal Integrity: Res; Simulation: RESISTOR; Supplier: Digi-Key; Supplier Part Number: 2019-RK73H2ATTD1004FDKR-ND; Technology: THICK FILM; Termination: SMD/SMT; Tolerance: 1%; Value: 1M; Voltage Rating (DC): 150V; Width: 1.25mm
R109 = Res3: RES 221 OHM 1% 1/4W 1206; Manufacturer: Stackpole Electronics; Manufacturer Part Number: RMCF1206FT221R; Max Power Dissipation: 250mW; Resistance: 221R; Temperature Coefficient: 100ppm/°C; Case Code (Imperial): 1206; Case Code (Metric): 3216; Case/Package: 1206; Component Kind: Standard; Composition: Thick Film; Contact Plating: Matte,Tin; Depth: 1.6mm; Features: Automotive AEC-Q200; Height: 0.028inch; Length: 3.2mm; Library Name: Miscellaneous Devices.IntLib; Max Operating Temperature: 155°C; Military Standard: Not; Min Operating Temperature: -55°C; Mount: Surface Mount; Number of Terminations: 2; Packaging: Tape and Reel; Radiation Hardening: No; Signal Integrity: Res; Simulation: RESISTOR; Supplier: Digi-Key; Supplier Part Number: RMCF1206FT221RCT-ND; Tolerance: 1%; Width: 0.063inch
R111 = Res3: RES 49.9 OHM 1% 1/4W 0805; Manufacturer: KOA Speer; Manufacturer Part Number: RK73H2ATTD49R9F; Max Power Dissipation: 250mW; Power Rating: 125mW; Resistance: 49.9R; Temperature Coefficient: 100ppm/°C; Voltage Rating: 150V; Case Code (Imperial): 0805; Case Code (Metric): 2012; Case/Package: 0805; Component Kind: Standard; Composition: Thick Film; Construction: Rectangular; Contact Plating: Matte,Tin; Depth: 1.25mm; Features: Automotive AEC-Q200; Height: 0.5mm; Lead Free: Lead Free; Length: 2mm; Library Name: Miscellaneous Devices.IntLib; Max Operating Temperature: 155°C; Military Standard: Not; Min Operating Temperature: -55°C; Mount: Surface Mount; Number of Pins: 2; Number of Terminations: 2; Package Quantity: 5000; Packaging: Tape and Reel; Radiation Hardening: No; REACH SVHC: Unknown; Resistance Tolerance: 1%; Resistor Type: Thick Film; RoHS Compliant: Yes; Series: RK73H; Signal Integrity: Res; Simulation: RESISTOR; Supplier: Digi-Key; Supplier Part Number: 2019-RK73H2ATTD49R9FDKR-ND; Technology: THICK FILM; Termination: SMD/SMT; Tolerance: 1%; Value: 49.9; Voltage Rating (DC): 150V; Width: 1.25mm
R112 = Res3: RES 3.48K OHM 1% 1/4W 1206; Manufacturer: Stackpole Electronics; Manufacturer Part Number: RMCF1206FT3K48; Max Power Dissipation: 250mW; Resistance: 3.48kR; Temperature Coefficient: 100ppm/°C; Case Code (Imperial): 1206; Case Code (Metric): 3216; Case/Package: 1206; Component Kind: Standard; Composition: Thick Film; Contact Plating: Tin,Matte; Depth: 1.6mm; Features: Automotive AEC-Q200; Height: 0.028inch; Lead Free: Lead Free; Length: 0.126inch; Library Name: Miscellaneous Devices.IntLib; Max Operating Temperature: 155°C; Military Standard: Not; Min Operating Temperature: -55°C; Mount: Surface Mount; Number of Terminations: 2; Package Quantity: 5000; Packaging: Tape and Reel; Power Rating: 250mW; Radiation Hardening: No; Resistor Type: Thick Film; RoHS Compliant: Yes; Series: RMCF; Signal Integrity: Res; Simulation: RESISTOR; Supplier: Digi-Key; Supplier Part Number: RMCF1206FT3K48CT-ND; Tolerance: 1%; Width: 0.063inch
R113 = Res3: RES 162 OHM 1% 1/4W 1206; Manufacturer: Stackpole Electronics; Manufacturer Part Number: RMCF1206FT162R; Max Power Dissipation: 250mW; Resistance: 162R; Temperature Coefficient: 100ppm/°C; Case Code (Imperial): 1206; Case Code (Metric): 3216; Case/Package: 1206; Component Kind: Standard; Composition: Thick Film; Contact Plating: Tin,Matte; Depth: 1.6mm; Features: Automotive AEC-Q200; Height: 0.028inch; Lead Free: Lead Free; Length: 0.126inch; Library Name: Miscellaneous Devices.IntLib; Max Operating Temperature: 155°C; Military Standard: Not; Min Operating Temperature: -55°C; Mount: Surface Mount; Number of Terminations: 2; Packaging: Tape and Reel; Power Rating: 250mW; Radiation Hardening: No; Resistor Type: Thick Film; RoHS Compliant: Yes; Series: RMCF; Signal Integrity: Res; Simulation: RESISTOR; Supplier: Digi-Key; Supplier Part Number: RMCF1206FT162RCT-ND; Tolerance: 1%; Width: 0.063inch
R114 = Res3: RES 2K OHM 1% 1/4W 0805; Manufacturer: KOA Speer; Manufacturer Part Number: RK73H2ATTD2001F; Max Power Dissipation: 250mW; Power Rating: 125mW; Resistance: 2kR; Temperature Coefficient: 100ppm/°C; Voltage Rating: 150V; Case Code (Imperial): 0805; Case Code (Metric): 2012; Case/Package: 0805; Component Kind: Standard; Composition: Thick Film; Construction: Rectangular; Contact Plating: Matte,Tin; Depth: 1.25mm; Features: Automotive AEC-Q200; Height: 0.5mm; Lead Free: Lead Free; Length: 2mm; Library Name: Miscellaneous Devices.IntLib; Max Operating Temperature: 155°C; Military Standard: Not; Min Operating Temperature: -55°C; Mount: Surface Mount; Number of Pins: 2; Number of Terminations: 2; Package Quantity: 5000; Packaging: Tape and Reel; Radiation Hardening: No; REACH SVHC: Unknown; Resistance Tolerance: 1%; Resistor Type: Thick Film; RoHS Compliant: Yes; Series: RK73H; Signal Integrity: Res; Simulation: RESISTOR; Supplier: Digi-Key; Supplier Part Number: 2019-RK73H2ATTD2001FDKR-ND; Technology: THICK FILM; Termination: SMD/SMT; Tolerance: 1%; Value: 2K; Voltage Rating (DC): 150V; Width: 1.25mm
R115 R116 = Res3: RES 1K OHM 1% 1/4W 0805; Manufacturer: KOA Speer; Manufacturer Part Number: RK73H2ATTD1001F; Max Power Dissipation: 250mW; Power Rating: 125mW; Resistance: 1kR; Temperature Coefficient: 100ppm/°C; Voltage Rating: 150V; Case Code (Imperial): 0805; Case Code (Metric): 2012; Case/Package: 0805; Component Kind: Standard; Composition: Thick Film; Construction: Rectangular; Contact Plating: Matte,Tin; Depth: 1.25mm; Features: Automotive AEC-Q200; Height: 0.5mm; Lead Free: Lead Free; Length: 2mm; Library Name: Miscellaneous Devices.IntLib; Max Operating Temperature: 155°C; Military Standard: Not; Min Operating Temperature: -55°C; Mount: Surface Mount; Number of Pins: 2; Number of Terminations: 2; Package Quantity: 5000; Packaging: Tape and Reel; Radiation Hardening: No; REACH SVHC: Unknown; Resistance Tolerance: 1%; Resistor Type: Thick Film; RoHS Compliant: Yes; Series: RK73H; Signal Integrity: Res; Simulation: RESISTOR; Supplier: Digi-Key; Supplier Part Number: 2019-RK73H2ATTD1001FDKR-ND; Termination: SMD/SMT; Tolerance: 1%; Value: 1K; Voltage Rating (DC): 150V; Width: 1.25mm
R117 R120 = Res3: RES SMD 0R5 OHM 1% 2W 2512; Manufacturer: Stackpole Electronics; Manufacturer Part Number: CSRN2512FKR500; Resistance: 500mR; Temperature Coefficient: 200ppm/°C; Case Code (Imperial): 2512; Case Code (Metric): 6432; Case/Package: 2512; Component Kind: Standard; Construction: Rectangular; Contact Plating: Tin,Matte; Depth: 3.15mm; Height: 0.028inch; Lead Free: Lead Free; Length: 6.35mm; Library Name: Miscellaneous Devices.IntLib; Max Operating Temperature: 155°C; Max Power Dissipation: 2W; Military Standard: Not; Min Operating Temperature: -55°C; Mount: Surface Mount; Number of Terminations: 2; Packaging: Tape and Reel; Power Rating: 2W; Radiation Hardening: No; Resistor Type: Thick Film; RoHS Compliant: Yes; Series: CSRN; Signal Integrity: Res; Simulation: RESISTOR; Size Code: 2512; Supplier: Digi-Key; Supplier Part Number: CSRN2512FKR500TR-ND; Value: 0R5; Width: 0.124inch
R118 = Res3: RES 1.5K OHM 1% 3W 2512; Manufacturer: TE Connectivity CGS; Manufacturer Part Number: 35221K5JT; Number of Resistors: 1; Resistance: 1.5kR; Temperature Coefficient: 100ppm/°C; Tolerance: 5%; Voltage Rating: 250V; Case Code (Imperial): 2512; Case Code (Metric): 6432; Case/Package: 2512; Component Kind: Standard; Depth: 3.2mm; Height: 1.1mm; Length: 6.35mm; Library Name: Miscellaneous Devices.IntLib; Max Operating Temperature: 105°C; Min Operating Temperature: -55°C; Mount: Surface Mount; Number of Terminations: 2; Packaging: Tape and Reel; REACH SVHC: Unknown; Resistor Type: Thick Film,Power resistor; RoHS Compliant: Yes; Signal Integrity: Res; Simulation: RESISTOR; Supplier: Digi-Key; Supplier Part Number: A121168DKR-ND; Termination: Solder; Value: 1.5K; Voltage Rating (DC): 250V
R122 R137 R140 R141 = Res3: RES 499 OHM 1% 1/4W 0805; Manufacturer: KOA Speer; Manufacturer Part Number: RK73H2ATTD4990F; Max Power Dissipation: 250mW; Power Rating: 125mW; Resistance: 499R; Temperature Coefficient: 100ppm/°C; Voltage Rating: 150V; Case Code (Imperial): 0805; Case Code (Metric): 2012; Case/Package: 0805; Component Kind: Standard; Composition: Thick Film; Construction: Rectangular; Contact Plating: Matte,Tin; Depth: 1.25mm; Features: Automotive AEC-Q200; Height: 0.5mm; Lead Free: Lead Free; Length: 2mm; Library Name: Miscellaneous Devices.IntLib; Max Operating Temperature: 155°C; Military Standard: Not; Min Operating Temperature: -55°C; Mount: Surface Mount; Number of Terminations: 2; Package Quantity: 5000; Packaging: Tape and Reel; Radiation Hardening: No; Resistor Type: Thick Film; Series: RK73H; Signal Integrity: Res; Simulation: RESISTOR; Supplier: Digi-Key; Supplier Part Number: 2019-RK73H2ATTD4990FDKR-ND; Technology: THICK FILM; Termination: SMD/SMT; Tolerance: 1%; Value: 499; Voltage Rating (DC): 150V; Width: 1.25mm
R123 R135 = Res3: RES 10K OHM 1% 1/4W 0805; Manufacturer: KOA Speer; Manufacturer Part Number: RK73H2ATTD1002F; Max Power Dissipation: 250mW; Power Rating: 125mW; Resistance: 10kR; Temperature Coefficient: 100ppm/°C; Voltage Rating: 150V; Case Code (Imperial): 0805; Case Code (Metric): 2012; Case/Package: 0805; Component Kind: Standard; Composition: Thick Film; Construction: Rectangular; Contact Plating: Matte,Tin; Depth: 1.25mm; Features: Automotive AEC-Q200; Height: 0.5mm; Lead Free: Lead Free; Length: 2mm; Library Name: Miscellaneous Devices.IntLib; Max Operating Temperature: 155°C; Military Standard: Not; Min Operating Temperature: -55°C; Mount: Surface Mount; Number of Pins: 2; Number of Terminations: 2; Package Quantity: 5000; Packaging: Tape and Reel; Radiation Hardening: No; REACH SVHC: Unknown; Resistance Tolerance: 1%; Resistor Type: Thick Film; Series: RK73H; Signal Integrity: Res; Simulation: RESISTOR; Supplier: Digi-Key; Supplier Part Number: 2019-RK73H2ATTD1002FDKR-ND; Termination: SMD/SMT; Tolerance: 1%; Value: 10K; Voltage Rating (DC): 150V; Width: 1.25mm
R124 R138 R143 R144 = Res3: RES 100K OHM 1% 1/4W 0805; Manufacturer: KOA Speer; Manufacturer Part Number: RK73H2ATTD1003F; Power Rating: 125mW; Resistance: 100kR; Temperature Coefficient: 100ppm/°C; Voltage Rating: 150V; Case Code (Imperial): 0805; Case Code (Metric): 2012; Case/Package: 0805; Component Kind: Standard; Construction: Rectangular; Depth: 1.25mm; Height: 0.5mm; Lead Free: Lead Free; Length: 2mm; Library Name: Miscellaneous Devices.IntLib; Max Operating Temperature: 155°C; Military Standard: Not; Min Operating Temperature: -55°C; Number of Pins: 2; Package Quantity: 5000; Packaging: Tape and Reel; REACH SVHC: Unknown; Resistance Tolerance: 1%; Resistor Type: Thick Film; RoHS Compliant: Yes; Series: RK73H; Signal Integrity: Res; Simulation: RESISTOR; Supplier: Digi-Key; Supplier Part Number: 2019-RK73H2ATTD1003FDKR-ND; Termination: SMD/SMT; Tolerance: 1%; Value: 100K; Voltage Rating (DC): 150V; Width: 1.25mm
R125 = Res3: RES 105 OHM 1% 1/4W 1206; Manufacturer: Stackpole Electronics; Manufacturer Part Number: RMCF1206FT105R; Max Power Dissipation: 250mW; Resistance: 105R; Temperature Coefficient: 100ppm/°C; Case Code (Imperial): 1206; Case Code (Metric): 3216; Case/Package: 1206; Category: Chip SMD Resistors; Component Kind: Standard; ComponentLink1Description: https://www.seielect.com/catalog/SEI-RMCF_RMCP.pdf?src-supplier=Avnet; ComponentLink1URL: https://www.seielect.com/catalog/SEI-RMCF_RMCP.pdf?src-supplier=Avnet; ComponentLink2Description: https://www.seielect.com/Catalog/SEI-RMCF_RMCP.pdf?src-supplier=Digi-Key; ComponentLink2URL: https://www.seielect.com/Catalog/SEI-RMCF_RMCP.pdf?src-supplier=Digi-Key; ComponentLink3Description: https://www.seielect.com/catalog/SEI-Packaging.pdf?src-supplier=Digi-Key; ComponentLink3URL: https://www.seielect.com/catalog/SEI-Packaging.pdf?src-supplier=Digi-Key; Contact Plating: Tin,Matte; Features: Automotive AEC-Q200; Height: 0.028inch; Length: 0.126inch; Library Name: Miscellaneous Devices.IntLib; Max Operating Temperature: 155°C; Min Operating Temperature: -55°C; Mount: Surface Mount; Number of Terminations: 2; Packaging: Tape and Reel; Pricing 1: 1=0.10000, 10=0.03600, 100=0.01460, 1000=0.00655, 2500=0.00569 (USD); Radiation Hardening: No; Resistor Type: Thick Film; RoHS: Yes; RoHS Compliant: Yes; Series: RMCF; Signal Integrity: Res; Simulation: RESISTOR; Stock 1: 66223; Supplier: Digi-Key; Supplier Part Number: RMCF1206FT105RCT-ND; Tolerance: 1%; Width: 0.063inch
R126 = Res3: RES 100 OHM 1% 1/4W 0805; Manufacturer: KOA Speer; Manufacturer Part Number: RK73H2ATTD1000F; Max Power Dissipation: 250mW; Power Rating: 125mW; Resistance: 100R; Temperature Coefficient: 100ppm/°C; Voltage Rating: 150V; Case Code (Imperial): 0805; Case Code (Metric): 2012; Case/Package: 0805; Component Kind: Standard; Composition: Thick Film; Construction: Rectangular; Contact Plating: Matte,Tin; Depth: 1.25mm; Features: Automotive AEC-Q200; Height: 0.5mm; Lead Free: Lead Free; Length: 2mm; Library Name: Miscellaneous Devices.IntLib; Max Operating Temperature: 155°C; Military Standard: Not; Min Operating Temperature: -55°C; Mount: Surface Mount; Number of Pins: 2; Number of Terminations: 2; Package Quantity: 5000; Packaging: Tape and Reel; Radiation Hardening: No; REACH SVHC: Unknown; Resistance Tolerance: 1%; Resistor Type: Thick Film; RoHS Compliant: Yes; Series: RK73H; Signal Integrity: Res; Simulation: RESISTOR; Supplier: Digi-Key; Supplier Part Number: 2019-RK73H2ATTD1000FDKR-ND; Technology: THICK FILM; Termination: SMD/SMT; Tolerance: 1%; Value: 100; Voltage Rating (DC): 150V; Width: 1.25mm
R127 R142 = Res3: RES 49.9 OHM 1% 1/4W 0805; Manufacturer: KOA Speer; Manufacturer Part Number: RK73H2ATTD49R9F; Power Rating: 125mW; Resistance: 49.9R; Temperature Coefficient: 100ppm/°C; Voltage Rating: 150V; Case Code (Imperial): 0805; Case Code (Metric): 2012; Case/Package: 0805; Component Kind: Standard; Construction: Rectangular; Depth: 1.25mm; Height: 0.5mm; Lead Free: Lead Free; Length: 2mm; Library Name: Miscellaneous Devices.IntLib; Max Operating Temperature: 155°C; Military Standard: Not; Min Operating Temperature: -55°C; Mount: Surface Mount; Number of Pins: 2; Package Quantity: 5000; Packaging: Tape and Reel; REACH SVHC: Unknown; Resistor Type: Thick Film; RoHS Compliant: Yes; Series: RK73H; Signal Integrity: Res; Simulation: RESISTOR; Supplier: Digi-Key; Supplier Part Number: 2019-RK73H2ATTD49R9FDKR-ND; Technology: THICK FILM; Termination: SMD/SMT; Tolerance: 1%; Value: 49.9; Voltage Rating (DC): 150V; Width: 1.25mm
  R142: Resistance Tolerance: 1%
R128 = Res3: RES, CS, 2512, 10 ohm, 1%, 3.5W; Manufacturer: Stackpole Electronics; Manufacturer Part Number: CSRT2512FT10R0-UP; Category: Chip SMD Resistors; Component Kind: Standard; Library Name: Miscellaneous Devices.IntLib; Pricing 1: 1=0.67000, 10=0.56000, 25=0.48000, 50=0.43200, 100=0.37600, 250=0.33600, 500=0.27200, 1000=0.22000 (USD); Resistance: 10r0; RoHS: Yes; Signal Integrity: Res; Simulation: RESISTOR; Stock 1: 998; Supplier: Digi-Key; Supplier Part Number: 738-CSRT2512FT10R0-UPCT-ND
R130 R131 = Res3: Res Thick Film 2512 2.21 Ohm 1% 1W ±200ppm/C Pad SMD Automotive T/R; Manufacturer: KOA Speer; Manufacturer Part Number: RK73H3ATTE2R21F; Resistance: 2.21R; Temperature Coefficient: 100ppm/°C; Voltage Rating: 200V; Case Code (Imperial): 2512; Case Code (Metric): 6332; Case/Package: 2512; Category: Chip SMD Resistors; Component Kind: Standard; ComponentLink1Description: http://www.mouser.com/ds/2/219/RK73H-5715.pdf?src-supplier=Mouser; ComponentLink1URL: http://www.mouser.com/ds/2/219/RK73H-5715.pdf?src-supplier=Mouser; Construction: Rectangular; Depth: 3.1mm; Height: 0.6mm; Length: 6.3mm; Library Name: Miscellaneous Devices.IntLib; Max Operating Temperature: 155°C; Military Standard: Not; Min Operating Temperature: -55°C; Package Quantity: 4000; Packaging: Tape and Reel; Pricing 1: 1=0.42000, 10=0.32200, 100=0.13540, 1000=0.07470 (USD); Resistor Type: Thick Film; RoHS: Yes; RoHS Compliant: Yes; Series: RK73H; Signal Integrity: Res; Simulation: RESISTOR; Stock 1: 9; Supplier: Digi-Key; Supplier Part Number: 2019-RK73H3ATTE2R21FCT-ND; Termination: SMD/SMT; Tolerance: 1%; Width: 3.1mm
R132 R133 = Res3: RES 2R0 OHM 1% 2W 2512; Manufacturer: Yageo; Manufacturer Part Number: RC2512FK-7W2RL; Resistance: 2R; Case/Package: 2512; Component Kind: Standard; Library Name: Miscellaneous Devices.IntLib; RoHS Compliant: Yes; Signal Integrity: Res; Simulation: RESISTOR; Supplier: Digi-Key; Supplier Part Number: 13-RC2512FK-7W1RLDKR-ND; Tolerance: 1%; Value: 2R0
R134 = Res3: RES 10K OHM 1% 1/4W 0805; Manufacturer: KOA Speer; Manufacturer Part Number: RK73H2ATTD1002F; Power Rating: 125mW; Resistance: 10kR; Temperature Coefficient: 100ppm/°C; Voltage Rating: 150V; Case Code (Imperial): 0805; Case Code (Metric): 2012; Case/Package: 0805; Component Kind: Standard; Construction: Rectangular; Depth: 1.25mm; Height: 0.5mm; Lead Free: Lead Free; Length: 2mm; Library Name: Miscellaneous Devices.IntLib; Max Operating Temperature: 155°C; Military Standard: Not; Min Operating Temperature: -55°C; Mount: Surface Mount; Number of Pins: 2; Package Quantity: 5000; Packaging: Tape and Reel; REACH SVHC: Unknown; Resistance Tolerance: 1%; Resistor Type: Thick Film; RoHS Compliant: Yes; Series: RK73H; Signal Integrity: Res; Simulation: RESISTOR; Supplier: Digi-Key; Supplier Part Number: 2019-RK73H2ATTD1002FDKR-ND; Technology: THICK FILM; Termination: SMD/SMT; Tolerance: 1%; Value: 10K; Voltage Rating (DC): 150V; Width: 1.25mm
